# Altium schematic sheet sem_oled.SchDoc
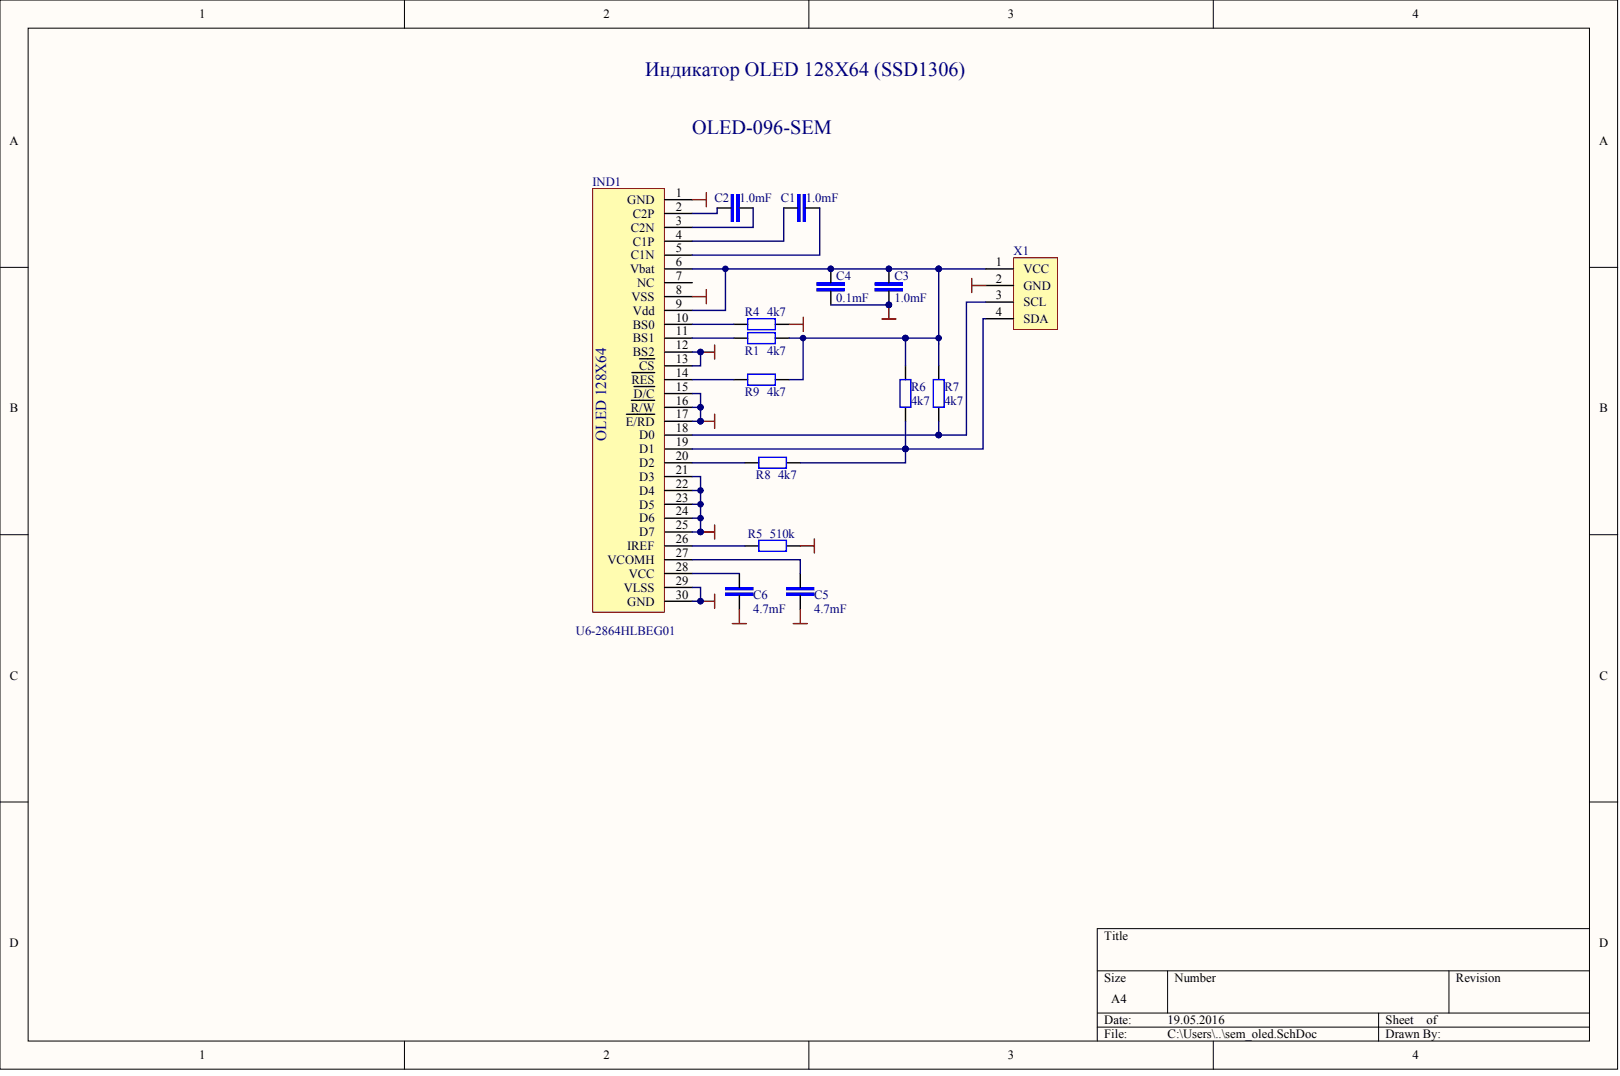
[diagram p0: sem_oled.SchDoc]

## Parts
C1  1.0mF
C2  1.0mF
C3  1.0mF
C4  0.1mF
C5  4.7mF
C6  4.7mF
IND1  U6-2864HLBEG01
R1  4k7
R4  4k7
R5  510k
R6  4k7
R7  4k7
R8  4k7
R9  4k7
X1  Conn4x1  (value not drawn)

## Nets
GND: C3.1 C4.1 C5.1 C6.1 IND1.1(GND) IND1.8(VSS) IND1.12(BS2) IND1.13(CS) IND1.15(D/C) IND1.16(R/W) IND1.17(E/RD) IND1.21(D3) IND1.22(D4) IND1.23(D5) IND1.24(D6) IND1.25(D7) IND1.29(VLSS) IND1.30(GND) R4.2 R5.2 X1.2(GND)
NetC1_1: C1.1 IND1.4(C1P)
NetC1_2: C1.2 IND1.5(C1N)
NetC2_1: C2.1 IND1.2(C2P)
NetC2_2: C2.2 IND1.3(C2N)
NetC3_2: C3.2 C4.2 IND1.6(Vbat) IND1.9(Vdd) R1.1 R6.2 R7.2 R9.1 X1.1(VCC)
NetC5_2: C5.2 IND1.27(VCOMH)
NetC6_2: C6.2 IND1.28(VCC)
NetIND1_10: IND1.10(BS0) R4.1
NetIND1_11: IND1.11(BS1) R1.2
NetIND1_14: IND1.14(RES) R9.2
NetIND1_18: IND1.18(D0) R7.1 X1.3(SCL)
NetIND1_19: IND1.19(D1) R6.1 R8.1 X1.4(SDA)
NetIND1_20: IND1.20(D2) R8.2
NetIND1_26: IND1.26(IREF) R5.1

Not connected: IND1 7(NC)
